# Altium schematic sheet New Javanes Sheet3.SchDoc
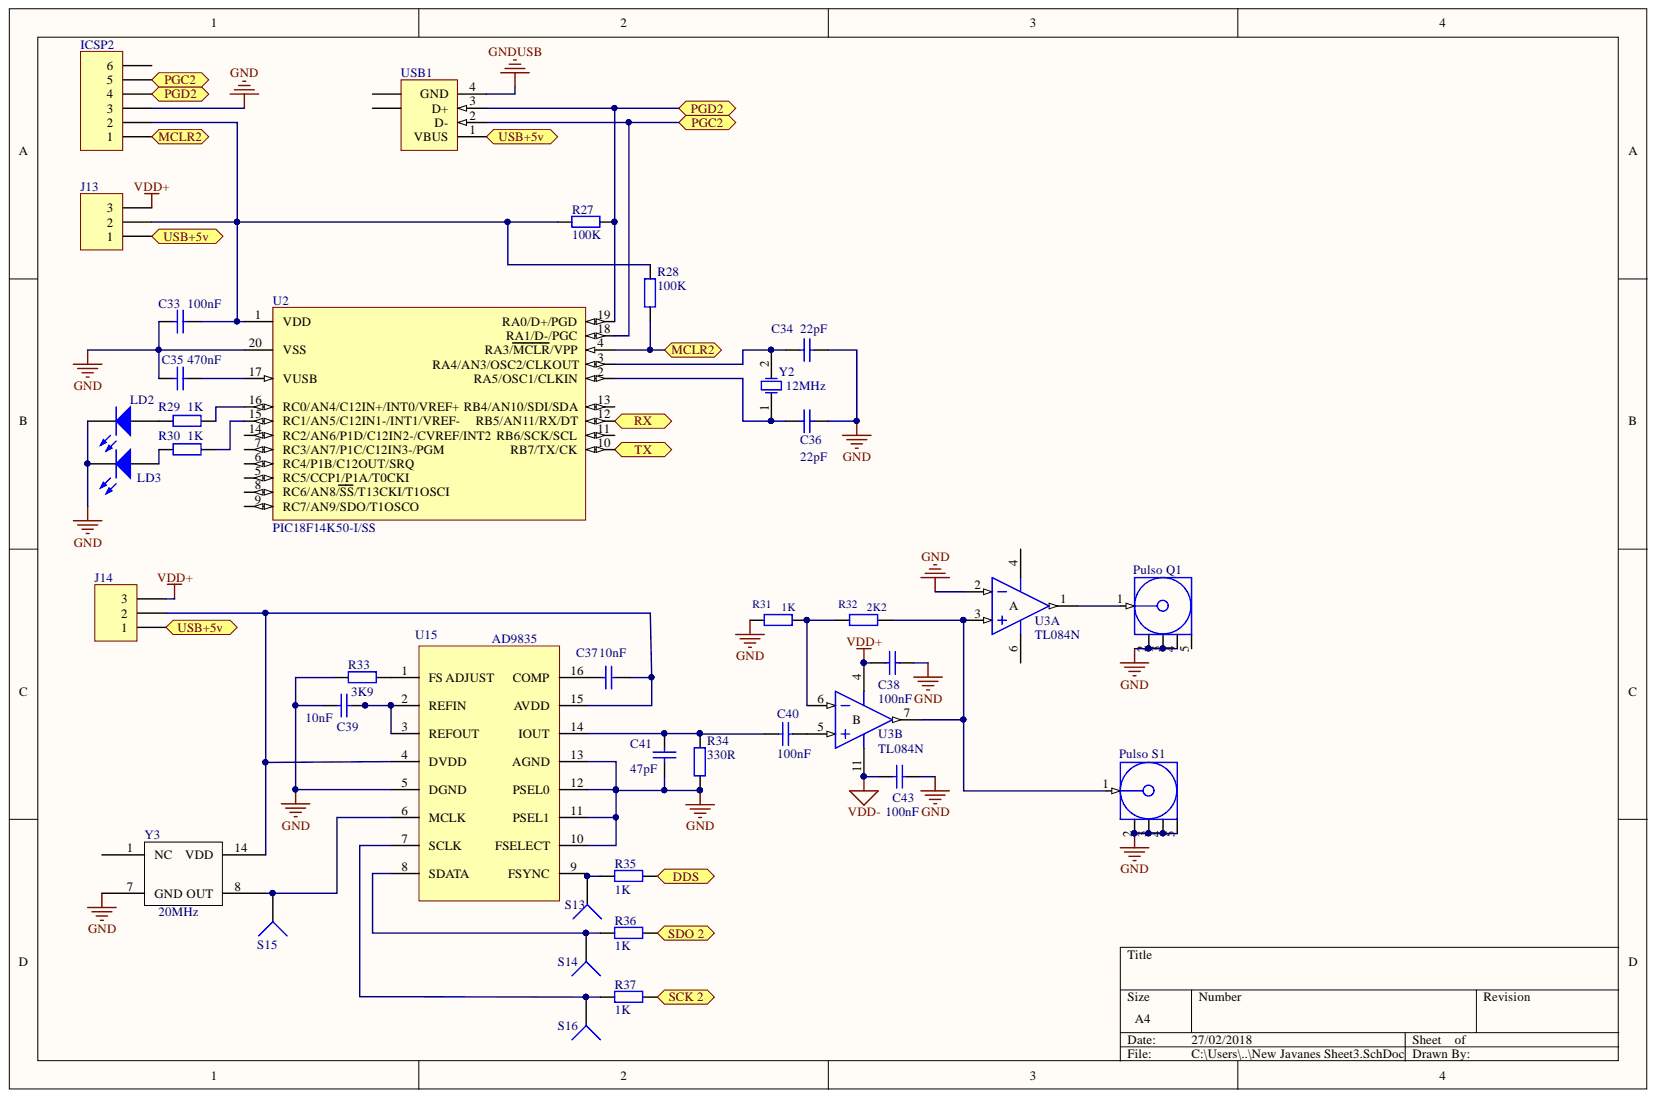
[diagram p0: New Javanes Sheet3.SchDoc]

## Parts
C33  value 100nF  [VP45-3.2]  (hidden comment: Cap)
C34  value 22pF  [VP45-3.2]  (hidden comment: Cap)
C35  value 470nF  [VP45-3.2]  (hidden comment: Cap)
C36  value 22pF  [VP45-3.2]  (hidden comment: Cap)
C37  value 10nF  [VP45-3.2]  (hidden comment: Cap)
C38  value 100nF  [VP45-3.2]  (hidden comment: Cap)
C39  value 10nF  [VP45-3.2]  (hidden comment: Cap)
C40  value 100nF  [VP45-3.2]  (hidden comment: Cap)
C41  value 47pF  [VP45-3.2]  (hidden comment: Cap)
C43  value 100nF  [VP45-3.2]  (hidden comment: Cap)
ICSP2  ICSP-U2  [HDR1X6]  (value not drawn)
J13  Header 3  [HDR1X3]  (value not drawn)
J14  Header 3  [HDR1X3]  (value not drawn)
LD2  LED1  [LED-1]  (value not drawn)
LD3  LED1  [LED-1]  (value not drawn)
Pulso_Q1  919-119J-51AX  [919-119J]  (value not drawn)
Pulso_S1  919-119J-51AX  [919-119J]  (value not drawn)
R27  value 100K  [AXIAL-0.4]  (hidden comment: Res2)
R28  value 100K  [AXIAL-0.4]  (hidden comment: Res2)
R29  value 1K  [AXIAL-0.4]  (hidden comment: Res2)
R30  value 1K  [AXIAL-0.4]  (hidden comment: Res2)
R31  value 1K  [AXIAL-0.3]  (hidden comment: Res2)
R32  value 2K2  [AXIAL-0.3]  (hidden comment: Res2)
R33  value 3K9  [AXIAL-0.4]  (hidden comment: Res2)
R34  value 330R  [AXIAL-0.4]  (hidden comment: Res2)
R35  value 1K  [AXIAL-0.4]  (hidden comment: Res2)
R36  value 1K  [AXIAL-0.4]  (hidden comment: Res2)
R37  value 1K  [AXIAL-0.4]  (hidden comment: Res2)
S13  Socket  [PIN1]  (value not drawn)
S14  Socket  [PIN1]  (value not drawn)
S15  Socket  [PIN1]  (value not drawn)
S16  Socket  [PIN1]  (value not drawn)
U2  PIC18F14K50-I/SS  [SSOP-SS20_L]
U3  TL084N  [N014]  (parts A,B)
U15  AD9835  [RU_16-L]
USB1  USB  [1-1470156]
Y2  12MHz  [HC-49U]
Y3  Xtal Osc by Igor  [Xtal Osc]  (value not drawn)

## Ports
DDS, MCLR2, PGC2, PGD2, RX, SCK 2, SDO 2, TX, USB+5v

## Nets
GND: C33.1 C34.2 C35.1 C36.2 C38.2 C39.1 C41.1 C43.2 ICSP2.3 LD2.2 LD3.2 Pulso_Q1.2 Pulso_Q1.3 Pulso_Q1.4 Pulso_S1.2 Pulso_S1.3 Pulso_S1.4 Pulso_S1.5 R31.2 R33.2 R34.1 U2.20(VSS) U3.2 U15.5(DGND) U15.10(FSELECT) U15.11(PSEL1) U15.12(PSEL0) U15.13(AGND) Y3.7(GND)
GNDUSB: USB1.4(GND)
VDD+: C38.1 J13.3 J14.3 U3.4
VDD-: C43.1 U3.11
DDS: R35.1 ; port DDS
MCLR2: ICSP2.1 R28.1 U2.4(RA3/MCLR/VPP) ; port MCLR2
PGC2: ICSP2.5 U2.18(RA1/D-/PGC) USB1.2(D-) ; port PGC2
PGD2: ICSP2.4 R27.1 U2.19(RA0/D+/PGD) USB1.3(D+) ; port PGD2
RX: U2.12(RB5/AN11/RX/DT) ; port RX
SCK 2: R37.1 ; port SCK 2
SDO 2: R36.1 ; port SDO 2
TX: U2.10(RB7/TX/CK) ; port TX
USB+5v: J13.1 J14.1 USB1.1(VBUS) ; port USB+5v
NetC33_2: C33.2 ICSP2.2 J13.2 R27.2 R28.2 U2.1(VDD)
NetC34_1: C34.1 U2.3(RA4/AN3/OSC2/CLKOUT) Y2.2
NetC35_2: C35.2 U2.17(VUSB)
NetC36_1: C36.1 U2.2(RA5/OSC1/CLKIN) Y2.1
NetC37_1: C37.1 U15.16(COMP)
NetC37_2: C37.2 J14.2 U15.4(DVDD) U15.15(AVDD) Y3.14(VDD)
NetC39_2: C39.2 U15.2(REFIN) U15.3(REFOUT)
NetC40_1: C40.1 U3.5
NetC40_2: C40.2 C41.2 R34.2 U15.14(IOUT)
NetLD2_1: LD2.1 R29.2
NetLD3_1: LD3.1 R30.2
NetPulso Q1_1: Pulso_Q1.1 U3.1
NetPulso S1_1: Pulso_S1.1 R32.1 U3.3 U3.7
NetR29_1: R29.1 U2.16(RC0/AN4/C12IN+/INT0/VREF+)
NetR30_1: R30.1 U2.15(RC1/AN5/C12IN1-/INT1/VREF-)
NetR31_1: R31.1 R32.2 U3.6
NetR33_1: R33.1 U15.1(FS_ADJUST)
NetR35_2: R35.2 S13.1 U15.9(FSYNC)
NetR36_2: R36.2 S14.1 U15.8(SDATA)
NetR37_2: R37.2 S16.1 U15.7(SCLK)
NetS15_1: S15.1 U15.6(MCLK) Y3.8(OUT)

Not connected: ICSP2 6; Pulso_Q1 5; U2 5(RC5/CCP1/P1A/T0CKI) 6(RC4/P1B/C12OUT/SRQ) 7(RC3/AN7/P1C/C12IN3-/PGM) 8(RC6/AN8/SS/T13CKI/T1OSCI) 9(RC7/AN9/SDO/T1OSCO) 11(RB6/SCK/SCL) 13(RB4/AN10/SDI/SDA) 14(RC2/AN6/P1D/C12IN2-/CVREF/INT2); USB1 5 6; Y3 1(NC)

## Library data of the parts
C33-C41 C43 = Cap: Capacitor; Component Kind: Standard; LatestRevisionDate: 17-Jul-2002; LatestRevisionNote: Re-released for DXP Platform.; Library Name: Miscellaneous Devices.IntLib; PackageReference: RAD-0.3; Published: 8-Jun-2000; Signal Integrity: Cap; Simulation: CAP; Publisher: Altium Limited
ICSP2 = Header 6: Header, 6-Pin; Component Kind: Standard; LatestRevisionDate: 17-Jul-2002; LatestRevisionNote: Re-released for DXP Platform.; Library Name: Miscellaneous Connectors.IntLib; Signal Integrity: Connector; Publisher: Altium Limited
J13 J14 = Header 3: Header, 3-Pin; Component Kind: Standard; LatestRevisionDate: 17-Jul-2002; LatestRevisionNote: Re-released for DXP Platform.; Library Name: Miscellaneous Connectors.IntLib; Signal Integrity: Connector; Publisher: Altium Limited
LD2 LD3 = LED1: Typical RED GaAs LED; Component Kind: Standard; LatestRevisionDate: 08-Jul-2005; LatestRevisionNote: Mech Layer 1 removed.; Library Name: Miscellaneous Devices.IntLib; PackageDescription: LED; 2 Leads; PackageReference: LED-1; Published: 8-Jun-2000; Simulation: LED1; Publisher: Altium Limited
Pulso_Q1 Pulso_S1 = 919-119J-51AX: MCX RF Coaxial PCB Connector, Thru-Hole, Right-Angle Mount Socket, Blunt Post Terminal, 50 Ohm Impedance; DrillSize: Corresponds to nominal value.; PCB_Layout: Complies with manufacturer's recommendation.; Component Kind: Standard; ComponentLink1Description: Manufacturer Link; ComponentLink1URL: http://www.amphenolrf.com/; ComponentLink2Description: Datasheet; ComponentLink2URL: http://www.amphenolrf.com/pdf/21.pdf; DatasheetDocument: 30-May-2003; Library Name: Amphenol RF Coaxial MCX.IntLib; PackageDocument: 04-Jul-1999; PackageReference: 919-119J; Published: 15-Jul-2004; Publisher: Altium Limited
R27-R37 = Res2: Resistor; Component Kind: Standard; LatestRevisionDate: 17-Jul-2002; LatestRevisionNote: Re-released for DXP Platform.; Library Name: Miscellaneous Devices.IntLib; PackageReference: AXIAL-0.4; Published: 8-Jun-2000; Signal Integrity: Res; Simulation: RESISTOR; Publisher: Altium Limited
U2 = PIC18F14K50-I/SS: USB Flash Microcontroller with nanoWatt XLP Technology, 20-pin SSOP, Industrial Temperature; Code_IPC: SOP65P780-20; Code_JEDEC: MO-150; Component Kind: Standard; ComponentLink1Description: Datasheet; ComponentLink1URL: http://ww1.microchip.com/downloads/en/DeviceDoc/41350C.pdf; ComponentLink2Description: Packaging Specification; ComponentLink2URL: http://www.microchip.com/stellent/groups/techpub_sg/documents/packagingspec/en012702.pdf; ComponentLink3Description: Microchip.com Home; ComponentLink3URL: http://www.microchip.com/; DatasheetVersion: revC, Mar-2009; Library Name: Microchip Microcontroller 8-Bit PIC18.IntLib; PackageDescription: 20-Lead Plastic Shrink Small Outline (SS) - 5.3mm Body [SSOP]; PackageReference: SSOP-SS20; PackageVersion: revBB, Aug-2009; Published: 2-Dec-2009; Supplier 1: Digi-Key; Supplier 2: Farnell; Supplier Part Number 1: PIC18F14K50-I/SS-ND; Supplier Part Number 2: 1648504; Publisher: Altium Limited
U3 = TL084N: JFET-Input Operational Amplifier; Component Kind: Standard; ComponentLink1Description: Manufacturer Link; ComponentLink1URL: http://www.ti.com/; ComponentLink2Description: Datasheet; ComponentLink2URL: http://www-s.ti.com/sc/ds/tl084.pdf; DatasheetVersion: Feb-1999; LatestRevisionDate: 04-Mar-2005; LatestRevisionNote: Stylized 3D Model Added.; Library Name: TI Operational Amplifier.IntLib; PackageDescription: DIP; 14 Leads; Row Spacing 7.62 mm; Pitch 2.54 mm; PackageReference: N014; PackageVersion: Oct-1995; PCB3D: N014; Published: 16-Jan-2001; Simulation: TL084; Publisher: Altium Limited
U15 = AD9835: DDS; Code_IPC: SOT23-3; Component Kind: Standard; ComponentLink1Description: Manufacturer Link; ComponentLink1URL: http://www.microchip.com; ComponentLink2Description: Datasheet; ComponentLink2URL: http://ww1.microchip.com/downloads/en/DeviceDoc/21653b.pdf; ComponentLink3Description: Packaging Specification; ComponentLink3URL: http://ww1.microchip.com/downloads/en/PackagingSpec/00049AW.pdf; DatasheetVersion: revB, Feb-2005; Library Name: AD9835.SchLib; PackageDescription: 3-Lead Plastic Small Outline Transistor (TT) [SOT-23]; PackageReference: SOT-23-TT3; PackageVersion: revAW, Oct-2008; Published: 29-Jan-2009; Publisher: Altium Limited
USB1 = 1-1470156-1: USB 1.1, Right Angle, Thru-Hole, B Type, Receptacle, 4 Position, White; ContactMaterial: Phosphor Bronze; ContactPlating: Gold over Nickel; DrillSize: Corresponds to nominal value.; PanelGround: Without; PCB_Layout: Complies with manufacturer's recommendation.; TerminationLength: 3.00mm [0.118in.]; Component Kind: Standard; ComponentLink1Description: Manufacturer Link; ComponentLink1URL: http://www.tycoelectronics.com/; ComponentLink2Description: Packaging Specification; ComponentLink2URL: http://ecommas.tycoelectronics.com/commerce/DocumentDelivery/DDEController?Action=showdoc&DocId=Customer+Drawing%7F1470156%7FO%7Ftif%7FEnglish%7FC_1470156_O.tif; DatasheetDocument: 02-Jun-2001; HousingMaterial: Glass-Filled Thermoplastic; Library Name: AMP Serial Bus USB.IntLib; PackageDocument: 02-Jun-2001; PackageReference: 1-1470156; Published: 15-Jul-2004; Signal Integrity: USB1.1; Publisher: Altium Limited
Y2 = ECS-10-13-1: Crystal Oscillator; Component Kind: Standard; ComponentLink1Description: Manufacturer Link; ComponentLink1URL: http://www.ecsxtal.com/; ComponentLink2Description: Datasheet; ComponentLink2URL: http://www.ecsxtal.com/pdf2/HC49U.PDF; DatasheetDocument: 18-Apr-2000; Library Name: ECS Crystal Oscillator.IntLib; PackageReference: HC-49U; Published: 17-Jul-2002; Publisher: Altium Limited
S13-S16 = Socket: Component Kind: Standard; Description: Socket; LatestRevisionDate: 17-Jul-2002; LatestRevisionNote: Re-released for DXP Platform.; Library Name: Miscellaneous Connectors.IntLib; Signal Integrity: Connector; Publisher: Altium Limited
Y3 = Xtal Osc by Igor: Component Kind: Standard; Description: Xtal Osc by Igor; Library Name: Schlib Xtal Osc DDS -Igor.SchLib
